# Altium schematic sheet MainBoard_Box.SchDoc(MainBoard_Box)
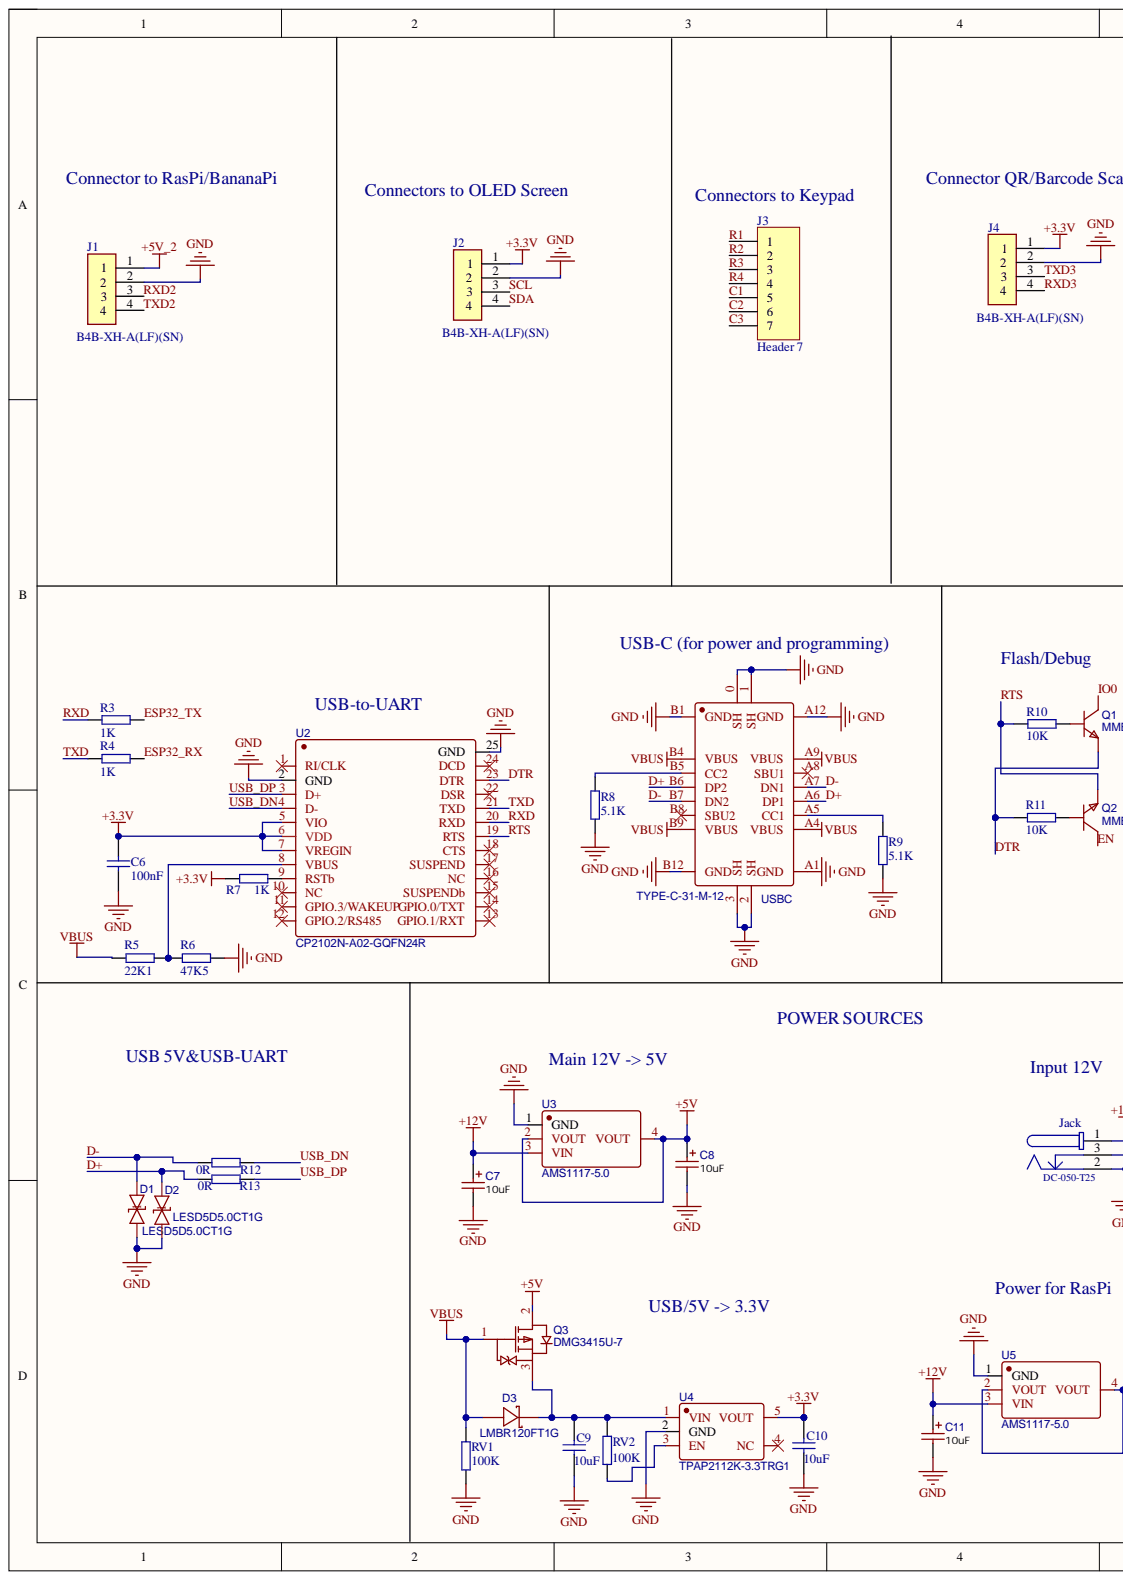
[diagram p0: MainBoard_Box.SchDoc, left]
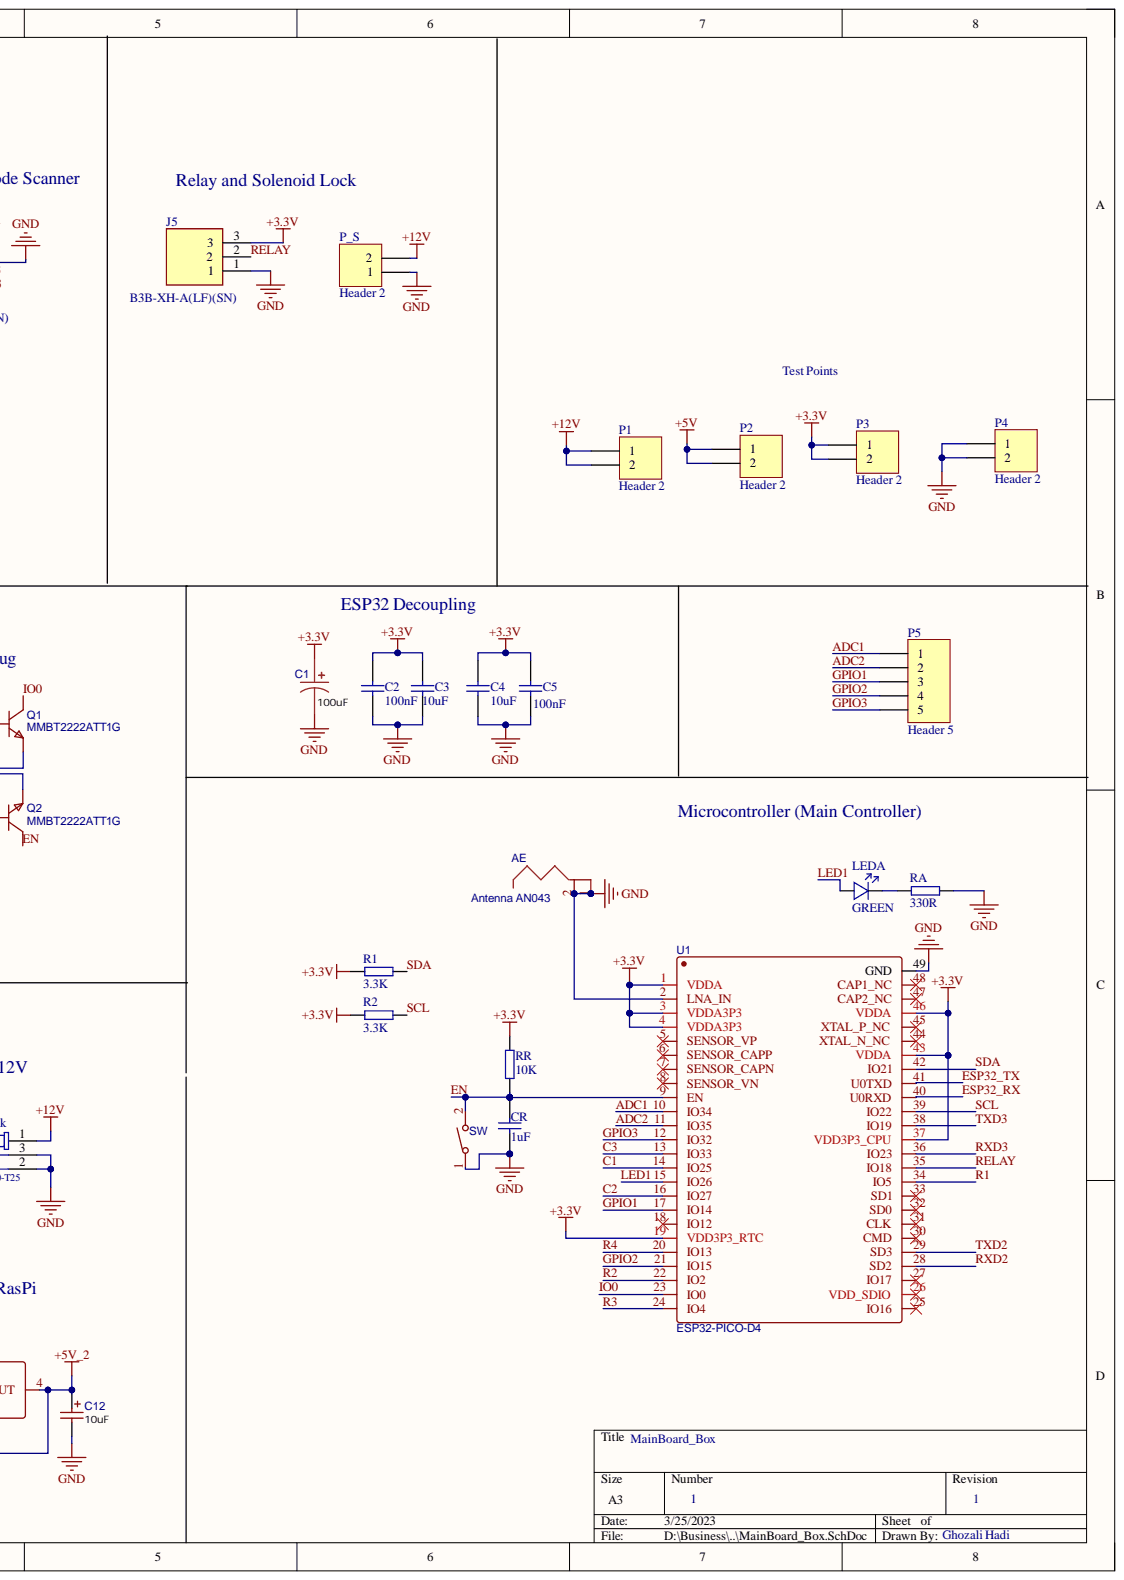
[diagram p1: MainBoard_Box.SchDoc, right]

## Parts
AE  Antenna AN043  [AN043]
C1  value 100uF  [CASE-C_6032]  (hidden comment: CA45-C-10V-100μF-K)
C2  value 100nF  [CAP0402]  (hidden comment: CC0402KRX7R7BB104)
C3  value 10uF  [CAP0402]  (hidden comment: CL05A106MQ5NUNC)
C4  value 10uF  [CAP0402]  (hidden comment: CL05A106MQ5NUNC)
C5  value 100nF  [CAP0402]  (hidden comment: CC0402KRX7R7BB104)
C6  value 100nF  [CAP0402]  (hidden comment: CC0402KRX7R7BB104)
C7  value 10uF  [CAP-SMD_L3.2-W1.6-R-RD]  (hidden comment: TAJA106K016RNJ)
C8  value 10uF  [CAP-SMD_L3.2-W1.6-R-RD]  (hidden comment: TAJA106K016RNJ)
C9  value 10uF  [CAP0402]  (hidden comment: CL05A106MQ5NUNC)
C10  value 10uF  [CAP0402]  (hidden comment: CL05A106MQ5NUNC)
C11  value 10uF  [CAP-SMD_L3.2-W1.6-R-RD]  (hidden comment: TAJA106K016RNJ)
C12  value 10uF  [CAP-SMD_L3.2-W1.6-R-RD]  (hidden comment: TAJA106K016RNJ)
CR  value 1uF  [CAP0402]  (hidden comment: CL05A105KA5NQNC)
D1  LESD5D5.0CT1G  [SOD-523_L1.2-W0.8-LS1.6-BI]
D2  LESD5D5.0CT1G  [SOD-523_L1.2-W0.8-LS1.6-BI]
D3  LMBR120FT1G  [SOD-123_L2.8-W1.8-LS3.7-RD]
J1  B4B-XH-A(LF)(SN)  [JST_B4B-XH-A(LF)(SN)]
J2  B4B-XH-A(LF)(SN)  [JST_B4B-XH-A(LF)(SN)]
J3  Header 7  [HDR1X7]
J4  B4B-XH-A(LF)(SN)  [JST_B4B-XH-A(LF)(SN)]
J5  B3B-XH-A(LF)(SN)  [JST_B3B-XH-A(LF)(SN)]
Jack  DC-050-T25  [694108106102]
LEDA  value GREEN  [LED0603P]  (hidden comment: 19-217/GHC-YR1S2/3T)
P1  Header 2  [HDR1X2]
P2  Header 2  [HDR1X2]
P3  Header 2  [HDR1X2]
P4  Header 2  [HDR1X2]
P5  Header 5  [HDR1X5]
P_S  Header 2  [HDR1X2]
Q1  MMBT2222ATT1G  [SC-75-3_L1.6-W0.8-P1.00-LS1.6-BR]
Q2  MMBT2222ATT1G  [SC-75-3_L1.6-W0.8-P1.00-LS1.6-BR]
Q3  DMG3415U-7  [SOT-23-3_L2.9-W1.6-P1.90-LS2.8-BR-CW]
R1  value 3.3K  [RES0402]  (hidden comment: RC0402FR-073K3L)
R2  value 3.3K  [RES0402]  (hidden comment: RC0402FR-073K3L)
R3  value 1K  [RES0402]  (hidden comment: 0402WGF1001TCE)
R4  value 1K  [RES0402]  (hidden comment: 0402WGF1001TCE)
R5  value 22K1  [RES0402]  (hidden comment: 0402WGF2212TCE)
R6  value 47K5  [RES0402]  (hidden comment: 0402WGF4752TCE)
R7  value 1K  [RES0402]  (hidden comment: 0402WGF1001TCE)
R8  value 5.1K  [RES0402]  (hidden comment: RC0402JR-075K1L)
R9  value 5.1K  [RES0402]  (hidden comment: RC0402JR-075K1L)
R10  value 10K  [RES0402]  (hidden comment: 0402WGF1002TCE)
R11  value 10K  [RES0402]  (hidden comment: 0402WGF1002TCE)
R12  value 0R  [RES0402]  (hidden comment: RC0402FR-070RL)
R13  value 0R  [RES0402]  (hidden comment: RC0402FR-070RL)
RA  value 330R  [RES0402]  (hidden comment: 0402WGF3300TCE)
RR  value 10K  [RES0402]  (hidden comment: 0402WGF1002TCE)
RV1  value 100K  [RES0402]  (hidden comment: RC0402FR-07100KL)
RV2  value 100K  [RES0402]  (hidden comment: RC0402FR-07100KL)
SW  TS-1092S-B3D2-G  [SW-SMD_L6.1-W3.6-LS7.9]  (value not drawn)
U1  ESP32-PICO-D4  [QFN-48_L7.0-W7.0-P0.50-BL-EP5.4]
U2  CP2102N-A02-GQFN24R  [QFN-24_L4.0-W4.0-P0.50-TL-EP2.6]
U3  AMS1117-5.0  [SOT-223_L6.5-W3.5-P2.30-LS7.0-BR]
U4  TPAP2112K-3.3TRG1  [SOT-23-5_L2.9-W1.6-P0.95-LS2.8-BR]
U5  AMS1117-5.0  [SOT-223_L6.5-W3.5-P2.30-LS7.0-BR]
USBC  TYPE-C-31-M-12  [USB-C_SMD-TYPE-C-31-M-12]

## Nets
+3.3V: C1.1 C2.2 C3.2 C4.2 C5.2 C6.2 C10.2 J2.1 J4.1 J5.3 P3.1 P3.2 R1.1 R2.1 R7.2 RR.1 U1.1(VDDA) U1.3(VDDA3P3) U1.4(VDDA3P3) U1.19(VDD3P3_RTC) U1.37(VDD3P3_CPU) U1.43(VDDA) U1.46(VDDA) U2.5(VIO) U2.6(VDD) U2.7(VREGIN) U4.5(VOUT)
+5V: C8.1 P2.1 P2.2 Q3.2 U3.2(VOUT) U3.4(VOUT)
+5V_2: C12.1 J1.1 U5.2(VOUT) U5.4(VOUT)
+12V: C7.1 C11.1 Jack.1 P1.1 P1.2 P_S.2 U3.3(VIN) U5.3(VIN)
GND: AE.1 AE.2 C1.2 C2.1 C3.1 C4.1 C5.1 C6.1 C7.2 C8.2 C9.1 C10.1 C11.2 C12.2 CR.1 D1.2 D2.2 J1.2 J2.2 J4.2 J5.1 Jack.2 Jack.3 P4.1 P4.2 P_S.1 R6.2 R8.2 R9.2 RA.1 RV1.1 SW.1 U1.2(LNA_IN) U1.49(GND) U2.2(GND) U2.25(GND) U3.1(GND) U4.2(GND) U5.1(GND) USBC.0(SH) USBC.1(SH) USBC.2(SH) USBC.3(SH) USBC.A1(GND) USBC.A12(GND) USBC.B1(GND) USBC.B12(GND)
VBUS: D3.2 Q3.1 R5.1 RV1.2 USBC.A4(VBUS) USBC.A9(VBUS) USBC.B4(VBUS) USBC.B9(VBUS)
ADC1: P5.1 U1.10(IO34)
ADC2: P5.2 U1.11(IO35)
C1: J3.5 U1.14(IO25)
C2: J3.6 U1.16(IO27)
C3: J3.7 U1.13(IO33)
D+: D2.1 R13.2 USBC.A6(DP1) USBC.B6(DP2)
D-: D1.1 R12.2 USBC.A7(DN1) USBC.B7(DN2)
DTR: Q1.2 R11.1 U2.23(DTR)
EN: CR.2 Q2.3 RR.2 SW.2 U1.9(EN)
ESP32_RX: R4.1 U1.40(U0RXD)
ESP32_TX: R3.1 U1.41(U0TXD)
GPIO1: P5.3 U1.17(IO14)
GPIO2: P5.4 U1.21(IO15)
GPIO3: P5.5 U1.12(IO32)
IO0: Q1.3 U1.23(IO0)
LED1: LEDA.1 U1.15(IO26)
R1: J3.1 U1.34(IO5)
R2: J3.2 U1.22(IO2)
R3: J3.3 U1.24(IO4)
R4: J3.4 U1.20(IO13)
RELAY: J5.2 U1.35(IO18)
RTS: Q2.2 R10.1 U2.19(RTS)
RXD: R3.2 U2.20(RXD)
RXD2: J1.3 U1.28(SD2)
RXD3: J4.4 U1.36(IO23)
SCL: J2.3 R2.2 U1.39(IO22)
SDA: J2.4 R1.2 U1.42(IO21)
TXD: R4.2 U2.21(TXD)
TXD2: J1.4 U1.29(SD3)
TXD3: J4.3 U1.38(IO19)
USB_DN: R12.1 U2.4(D-)
USB_DP: R13.1 U2.3(D+)
NetC9_2: C9.2 D3.1 Q3.3 RV2.2 U4.1(VIN)
NetLEDA_2: LEDA.2 RA.2
NetQ1_1: Q1.1 R10.2
NetQ2_1: Q2.1 R11.2
NetR5_2: R5.2 R6.1 U2.8(VBUS)
NetR7_1: R7.1 U2.9(RSTb)
NetR8_1: R8.1 USBC.B5(CC2)
NetR9_1: R9.1 USBC.A5(CC1)
NetRV2_1: RV2.1 U4.3(EN)

Not connected: U1 5(SENSOR_VP) 6(SENSOR_CAPP) 7(SENSOR_CAPN) 8(SENSOR_VN) 18(IO12) 25(IO16) 26(VDD_SDIO) 27(IO17) 30(CMD) 31(CLK) 32(SD0) 33(SD1) 44(XTAL_N_NC) 45(XTAL_P_NC) 47(CAP2_NC) 48(CAP1_NC); U2 1(RI/CLK) 10(NC) 11(GPIO.3/WAKEUP) 12(GPIO.2/RS485) 13(GPIO.1/RXT) 14(GPIO.0/TXT) 15(SUSPENDb) 16(NC) 17(SUSPEND) 18(CTS) 22(DSR) 24(DCD); U4 4(NC); USBC A8(SBU1) B8(SBU2)

## Library data of the parts
AE = Antenna AN043: Small Size 2.4 GHz PCB antenna; MF: Texas Instruments; Component Kind: Standard; MP: AN043; Library Name: ApolloLibrary.IntLib
C1 = Cap_100uF: 100uF 10V -55℃~+125℃ 300mΩ@100kHz ±10% CASE-C_6032 Tantalum Capacitors; MF: TORCH; Component Kind: Standard; JLCPCB Part #: C171664; MP: CA45-C-10V-100μF-K; Package: CASE-C-6032; Library Name: RiderDome.IntLib
C2 C5 C6 = CAP-SM-0402-X7R-100NF: 16V 100nF X7R ±10% 0402 Multilayer Ceramic Capacitors MLCC; Database Table Name: capacitors$; MF: YAGEO; Component Kind: Standard; LCSC Part #: C60474; Library Name: electronpowered.dblib; MP: CC0402KRX7R7BB104; Package: 0402
C3 C4 C9 C10 = CAP-SM-0402-X5R-10UF: 6.3V 10uF X5R ±20% 0402 Multilayer Ceramic Capacitors MLCC; Database Table Name: capacitors$; MF: SAMSUNG; Component Kind: Standard; LCSC Part #: C15525; Library Name: electronpowered.dblib; MP: CL05A106MQ5NUNC; Package: 0402
C7 C8 C11 C12 = TAJA106K016RNJ: TANTALUM CAPACITORS 10UF 16V CASE-A_3216; MF: AVX; SMT Type: Basic; BOM_Supplier Part: LCSC; Component Kind: Standard; LCSC Part #: C7171; MP: TAJA106K016RNJ; Package: CASE-A_3216; Library Name: BuckleLibrary.IntLib
CR = CAP-SM-0402-X5R-1UF: MULTILAYER CERAMIC CAPACITORS MLCC - SMD/SMT 1UF 25V 0402; Manufacturer: Samsung Electro-Mechanics; Database Table Name: capacitors$; Component Kind: Standard; LCSC Part #: C52923; Library Name: electronpowered.dblib; Package: 0402; Part Number: CL05A105KA5NQNC
D1 D2 = LESD5D5.0CT1G: 18.6V 9.4A (8/20us) 5V Bidirectional 5.6V SOD-523 ESD Protection Devices; MF: Leshan Radio; Component Kind: Standard; LCSC Part #: C383211; MP: LESD5D5.0CT1G; Package: SOD-523; Library Name: RiderDome.IntLib
D3 = LMBR120FT1G: 20V 500mV@1A 1A SOD-123 Schottky Barrier Diodes (SBD); MF: LRC; Component Kind: Standard; JLCPCB Part #: C81143; MP: LMBR120FT1G; Package: SOD-123; Library Name: GameDev.IntLib
J1 J2 J4 = B4B-XH-A(LF)(SN): Connector Header Through Hole 4 position 0.098 _2.50mm_; MF: JST Sales; MP: B4B-XH-A_LF__SN_; Availability: Unavailable; Component Kind: Standard; Package: None; Price: None; SnapEDA_Link: https://www.snapeda.com/parts/B4B-XH-A%28LF%29%28SN%29/JST%20Sales%20America%20Inc./view-part/447494/?ref=snap; Library Name: AquaponicsLibrary.IntLib
J3 = Header 7: Header, 7-Pin; Component Kind: Standard; LatestRevisionDate: 17-Jul-2002; LatestRevisionNote: Re-released for DXP Platform.; Library Name: Miscellaneous Connectors.IntLib; Signal Integrity: Connector; Publisher: Altium Limited
J5 = B3B-XH-A(LF)(SN): Connector Header Through Hole 3 position 0.098 (2.50mm); MF: JST Sales; Availability: Warning; Component Kind: Standard; DigiKey-Purchase-URL: https://snapeda.com/shop?store=DigiKey&id=231271; MP: B3B-XH-A(LF)(SN); Package: None; Price: None; SnapEDA_Link: https://www.snapeda.com/parts/B3B-XH-A(LF)(SN)/JST%20Sales%20America%20Inc./view-part/231271/?ref=snap; Library Name: AquaponicsLibrary.IntLib
Jack = DC Jack SMD: 3A 12V SMD AC/DC Power Connectors; MF: SOFNG; Component Kind: Standard; ComponentLink1Description: Manufacturer; ComponentLink1URL: http://www.we-online.de; ComponentLink2Description: Datasheet; ComponentLink2URL: http://katalog.we-online.de/em/datasheet/6941xx106102.pdf; JLCPCB Part #: C113716; MP: DC-050-T25; Package: SMD; Library Name: AquaponicsLibrary.IntLib
LEDA = LED-0603-RED: LIGHT EMITTING DIODES (LED) GREEN 520~535NM 112~258MCD@20MA TOP VIEW 0603; Manufacturer: Everlight Elec; Database Table Name: misc$; Component Kind: Standard; JLCPCB Part #: C72043; Library Name: electronpowered.dblib; Package: 0603; Part Number: 19-217/GHC-YR1S2/3T
P1-P4 P_S = Header 2: Header, 2-Pin; Component Kind: Standard; LatestRevisionDate: 17-Jul-2002; LatestRevisionNote: Re-released for DXP Platform.; Publisher: Altium Limited
  P1-P4: Library Name: Miscellaneous Connectors.IntLib; Signal Integrity: Connector
  P_S: Library Name: AquaponicsLibrary.IntLib
P5 = Header 5: Header, 5-Pin; Component Kind: Standard; LatestRevisionDate: 17-Jul-2002; LatestRevisionNote: Re-released for DXP Platform.; Library Name: AquaponicsLibrary.IntLib; Publisher: Altium Limited
Q1 Q2 = MMBT2222ATT1G: NPN 600mA 40V 150mW SC-75(SOT-523) Transistors (NPN/PNP); MF: ON Semiconductor; Component Kind: Standard; LCSC Part #: C94417; MP: MMBT2222ATT1G; Package: SC-75(SOT-523); Supplier: LCSC; Library Name: EMV-Library.IntLib
Q3 = DMG3415U-7: SOT-23-3 MOSFETs; MF: VBsemi Elec; MP: DMG3415U-7-VB; Component Kind: Standard; JLCPCB Part #: C709946; Package: SOT-23-3; Library Name: GameDev.IntLib
R1 R2 = RES-SM-0402-10K: 62.5mW Thick Film Resistors 50V ±100ppm/℃ ±1% -55℃~+155℃ 3.3kΩ 0402 Chip Resistor - Surface Mount; Database Table Name: resistors$; MF: YAGEO; Component Kind: Standard; JLCPCB Part #: C137992; Library Name: electronpowered.dblib; MP: RC0402FR-073K3L; Package: 0402
R3 R4 R7 = RES-SM-0402-1K: ±1% 1/16W Thick Film Resistors 50V ±100ppm/℃ -55℃~+155℃ 1kΩ 0402 Chip Resistor; Database Table Name: resistors$; MF: UNI-ROYAL(Uniroyal Elec); Component Kind: Standard; LCSC Part #: C11702; Library Name: electronpowered.dblib; MP: 0402WGF1001TCE; Package: 0402
R5 = RES-SM-0402-1K: ±1% 1/16W Thick Film Resistors 50V ±100ppm/℃ -55℃~+155℃ 22.1kΩ 0402 Chip Resistor - Surface Mount; Database Table Name: resistors$; MF: UNI-ROYAL(Uniroyal Elec); Component Kind: Standard; LCSC Part #: C43473; Library Name: electronpowered.dblib; MP: 0402WGF2212TCE; Package: 0402
R6 = RES-SM-0402-1K: ±1% 1/16W Thick Film Resistors 50V ±100ppm/℃ -55℃~+155℃ 47.5kΩ 0402 Chip Resistor - Surface Mount; Database Table Name: resistors$; MF: UNI-ROYAL(Uniroyal Elec); Component Kind: Standard; LCSC Part #: C25896; Library Name: electronpowered.dblib; MP: 0402WGF4752TCE; Package: 0402
R8 R9 = RES-SM-0402-10K: 5.1kΩ 0402 1/16W 50V ±5% ±100ppm/℃  Chip Resistor - Surface Mount; Database Table Name: resistors$; MF: YAGEO; Component Kind: Standard; LCSC Part #: C105873; Library Name: electronpowered.dblib; MP: RC0402JR-075K1L; Package: 0402
R10 R11 RR = RES-SM-0402-10K: CHIP RESISTOR - SURFACE MOUNT 10KOHMS ±1% 1/16W 0402; Database Table Name: resistors$; MF: Uniroyal Elec; Component Kind: Standard; LCSC Part #: C25744; Library Name: electronpowered.dblib; MP: 0402WGF1002TCE; Package: 0402
R12 R13 = RES-SM-0402-0R: 1/16W ±1% 0Ω 0402 Chip Resistor; Database Table Name: resistors$; MF: YAGEO; Component Kind: Standard; LCSC Part #: C106231; Library Name: electronpowered.dblib; MP: RC0402FR-070RL; Package: 0402
RA = RES-SM-0402-220R: CHIP RESISTOR - SURFACE MOUNT 330OHMS ±1% 1/16W 0402; Manufacturer: Uniroyal Elec; Database Table Name: resistors$; Component Kind: Standard; LCSC Part #: C25104; Library Name: electronpowered.dblib; Package: 0402; Part Number: 0402WGF3300TCE
RV1 RV2 = RES-SM-0402-1K: 62.5mW Thick Film Resistors 50V ±100ppm/℃ ±1% -55℃~+155℃ 100kΩ 0402 Chip Resistor - Surface Mount; Database Table Name: resistors$; MF: YAGEO; Component Kind: Standard; LCSC Part #: C60491; Library Name: electronpowered.dblib; MP: RC0402FR-07100KL; Package: 0402
SW = TS-1092S-B3D2-G: NO 3.6mm 2.5mm Gull Wing Gray Rectangle button Brick nogging SPST 6.1mm 250gf@±30gf SMD Tactile Switches; MF: Yuandi; Component Kind: Standard; JLCPCB Part #: C520860; MP: TS-1092S-B3D2-G; Package: SMD; Library Name: GameDev.IntLib
U1 = ESP32-PICO-D4: QFN-48_7x7x05P RF Transceiver ICs; MF: Espressif Systems; Component Kind: Standard; LCSC Part #: C193707; MP: ESP32-PICO-D4; Library Name: RiderDome.IntLib
U2 = CP2102N-A02-GQFN24R: QFN-24_4x4x05P USB ICs; MF: SILICON LABS; Component Kind: Standard; LCSC Part #: C969151; MP: CP2102N-A02-GQFN24R; Package: QFN-24_4x4x05P; Library Name: RiderDome.IntLib
U3 U5 = AMS1117-5.0: 68dB@(120Hz) 1A 1.3V@(1A) Fixed 5V~5V Positive 1 15V SOT-223 Linear Voltage Regulators (LDO); MF: AMS; Component Kind: Standard; JLCPCB Part #: C6187; Library Name: Box_Embedded.IntLib; MP: AMS1117-5.0; Package: SOT-223
U4 = TPAP2112K-3.3TRG1: SOT-23-5 Linear Voltage Regulators (LDO); MF: TECH PUBLIC; Component Kind: Standard; JLCPCB Part #: C3021085; MP: TPAP2112K-3.3TRG1; Package: SOT-23-5; Library Name: GameDev.IntLib
USBC = TYPE-C-31-M-12: 5A 1 16 Female Type-C SMD USB Connectors; MF: Korean Hroparts Elec; Component Kind: Standard; JLCPCB Part #: C165948; MP: TYPE-C-31-M-12; Package: SMD; Library Name: GameDev.IntLib
